# Altium schematic sheet TP_STM32_I2C.SchDoc
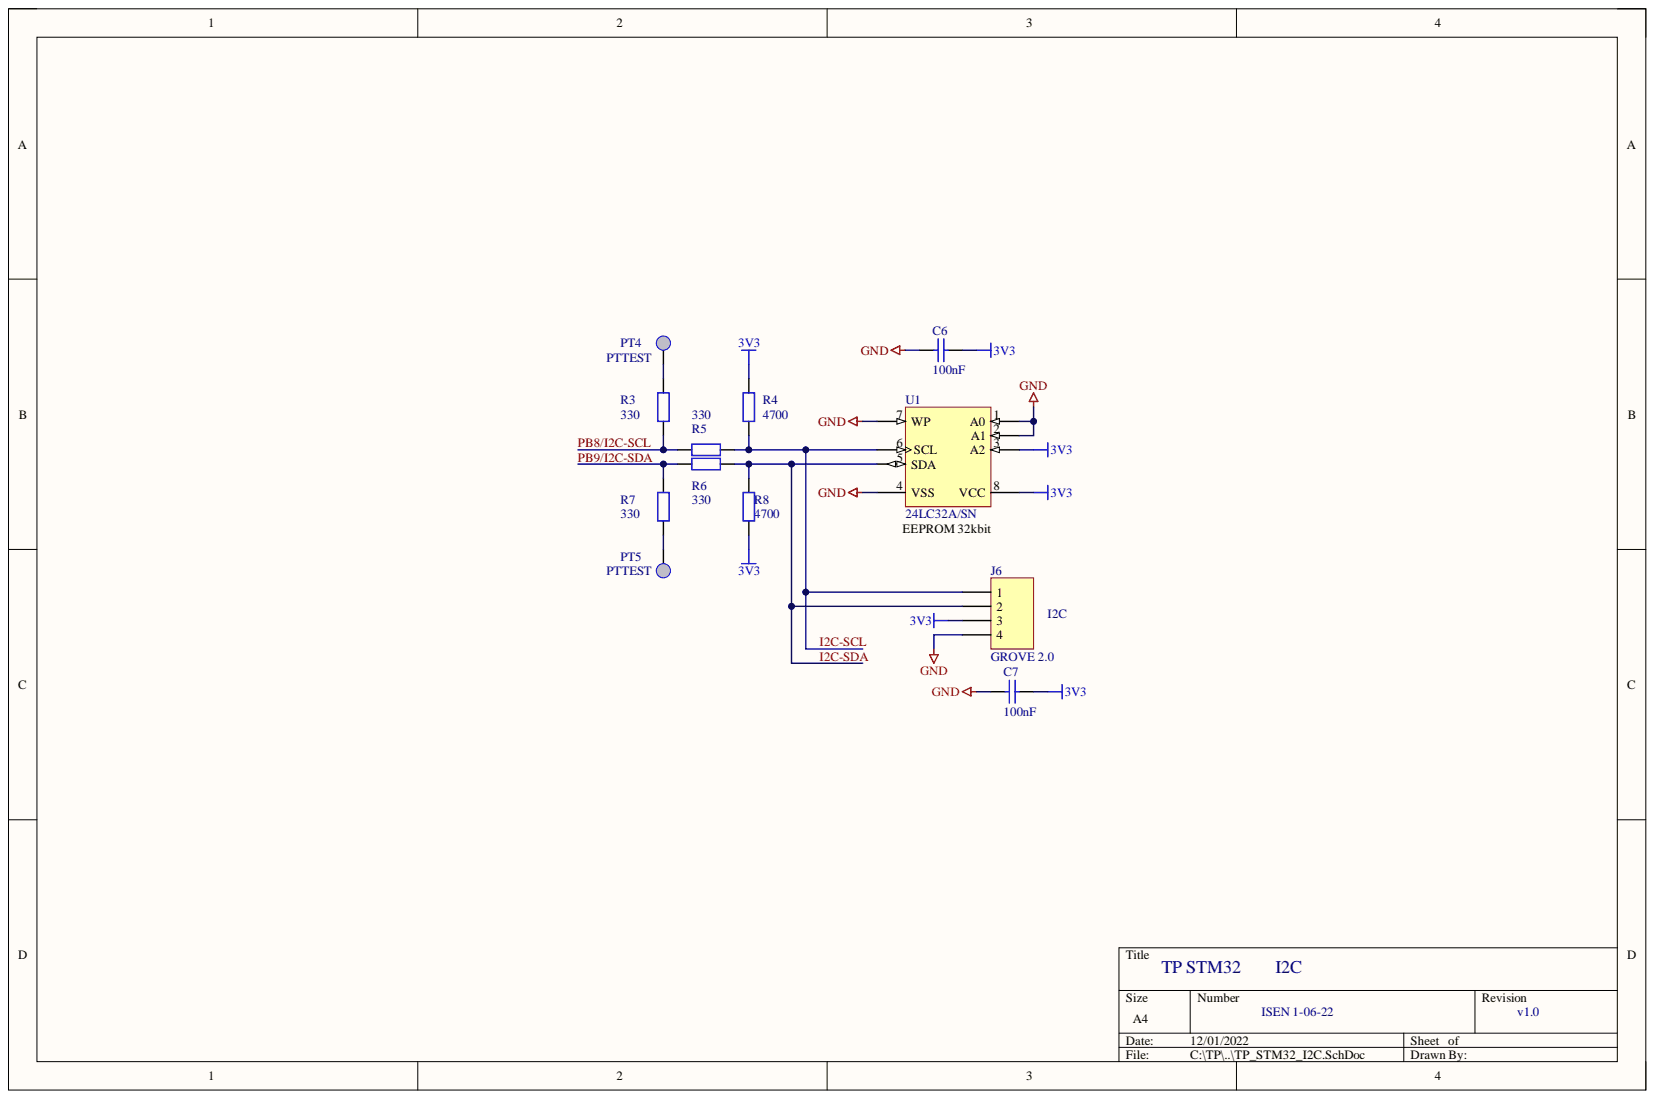
[diagram p0: TP_STM32_I2C.SchDoc]

## Parts
C6  100nF  [CC2012-0805]
C7  100nF  [CC2012-0805]
J6  GROVE 2.0  [GROVE4-2.0]
PT4  PTTEST  [PTEST]
PT5  PTTEST  [PTEST]
R3  330  [R2012-0805]
R4  4700  [R2012-0805]
R5  330  [R2012-0805]
R6  330  [R2012-0805]
R7  330  [R2012-0805]
R8  4700  [R2012-0805]
U1  24LC32A/SN  [SOIC-SN8_N]

## Nets
3V3: C6.1 C7.1 J6.3 R4.1 R8.1 U1.3(A2) U1.8(VCC)
GND: C6.2 C7.2 J6.4 U1.1(A0) U1.2(A1) U1.4(VSS) U1.7(WP)
I2C-SCL: J6.1 R4.2 R5.2 U1.6(SCL)
I2C-SDA: J6.2 R6.2 R8.2 U1.5(SDA)
PB8/I2C-SCL: R3.2 R5.1
PB9/I2C-SDA: R6.1 R7.2
NetPT4_1: PT4.1 R3.1
NetPT5_1: PT5.1 R7.1

## Library data of the parts
C6 C7 = Cap: Capacitor MLCC X7R 50V; Manufacturer: Generic Components; Class I: Passive; Class II: Capacitor; Component Kind: Standard; Library Name: Miscellaneous Devices.IntLib; Published: 8-Jun-2000; Revision: July-2002: Re-released for DXP Platform.; Signal Integrity: Cap; Simulation: CAP; Value: 100nF; Publisher: Altium Limited
J6 = MHDR1X4: Connector GROVE 4-Pin STRAIGHT pitch 2.0mm; Manufacturer: SEEED STUDIO; Component Kind: Standard; LatestRevisionDate: 17-Jul-2002; LatestRevisionNote: Re-released for DXP Platform.; Library Name: Miscellaneous Connectors.IntLib; Signal Integrity: Connector; Publisher: Altium Limited
PT4 PT5 = POINT-TEST: POINT TEST VERO 20-2136; Class I: Passive; Class II: Resistor; Component Kind: Standard; Library Name: STM8S.SCHLIB; Manufacturer: VERO; Published: 15/12/03; Revision: 1.0; Publisher: ISEN BREST
R3-R8 = Res2: Resistor; Manufacturer: Generic Components; Class I: Passive; Class II: Resistor; Component Kind: Standard; Library Name: Miscellaneous Devices.IntLib; Published: 8-Jun-2000; Revision: July-2002: Re-released for DXP Platform.; Signal Integrity: Res2; Simulation: RESISTOR; Publisher: Altium Limited
  R3 R5-R7: Value: 330
  R4 R8: Value: 4700
U1 = 24LC08B-E/SN: I2C Serial EEPROM, 32Kbit, 400kHz, 2.5V, 8-Pin SOIC 150mil; Code_IPC: SOIC127P600-8; Component Kind: Standard; Library Name: Microchip I2C Serial EEPROM.IntLib; Manufacturer Part Number: 24LC32A/SN; Mounting Technology: Surface Mount; PackageDescription: 8-Lead Plastic Small Outline (SN) - Narrow, 3.90mm Body [SOIC]; PackageReference: SOIC-SN8; PackageVersion: revBB, Aug-2009
